# Altium schematic sheet IMX6UL_ALPHA_ENET2.SchDoc(IMX6UL_ALPHA_ENET2)
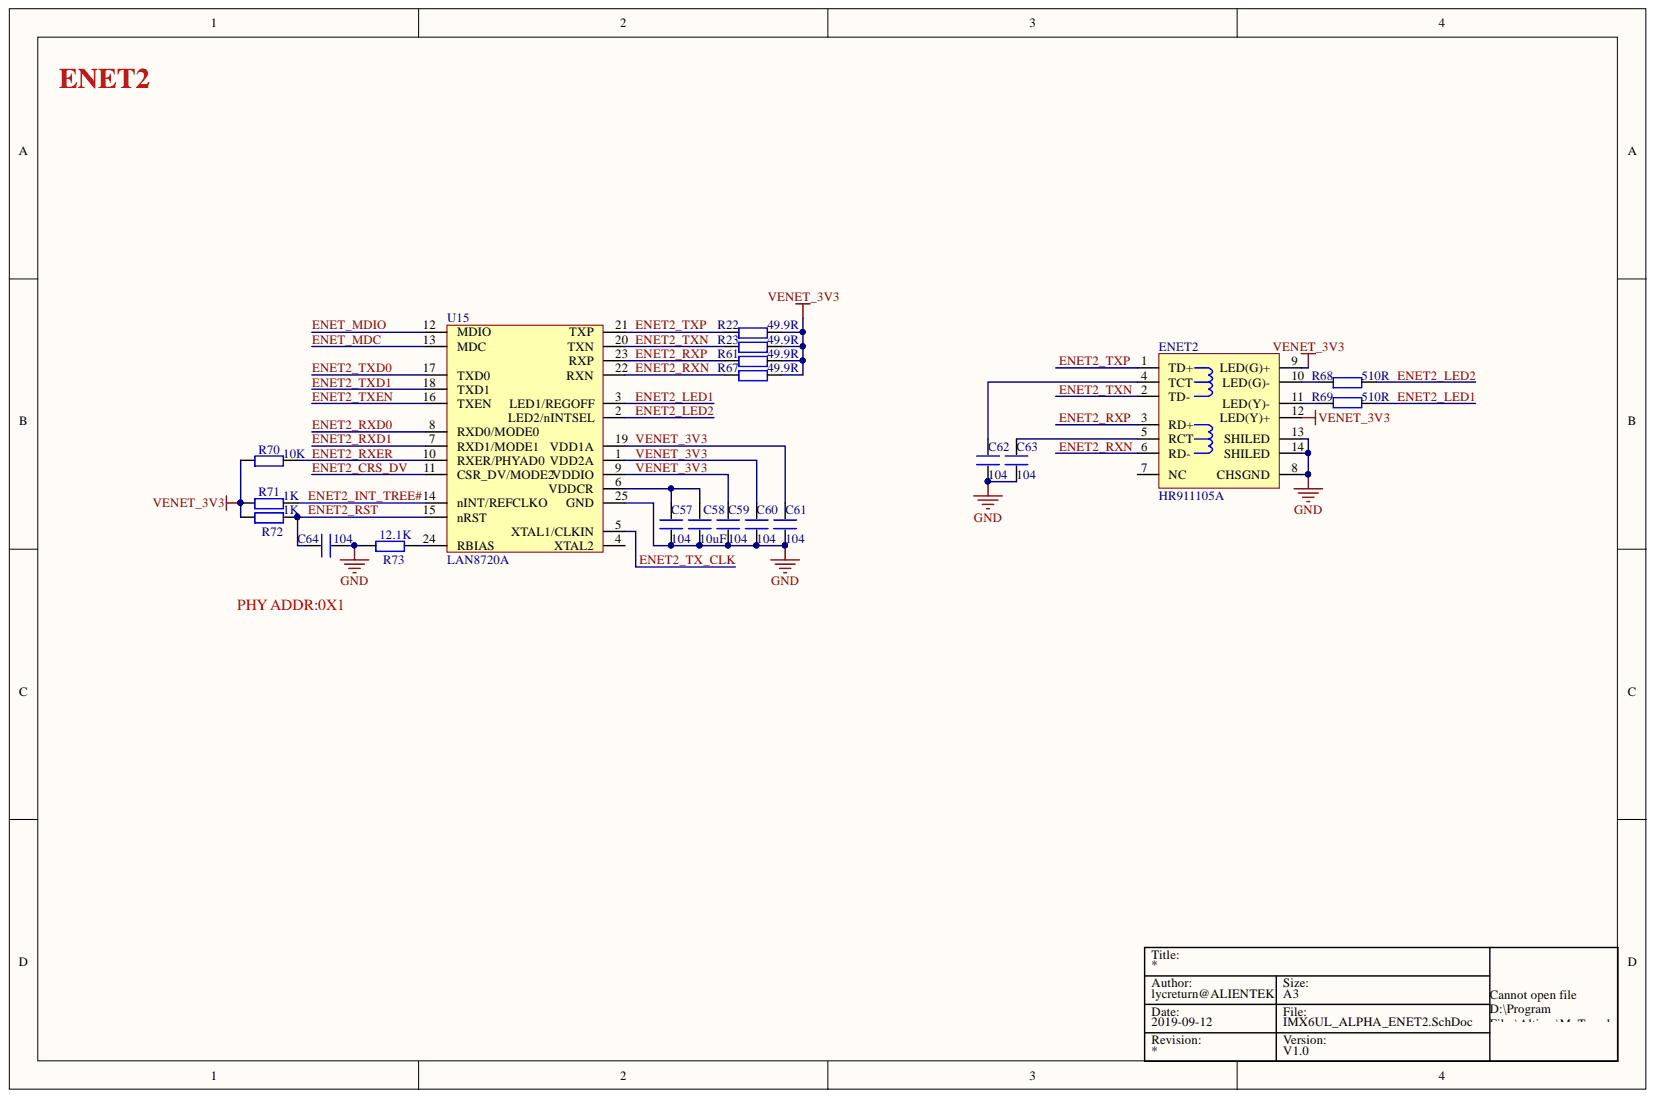
[diagram p0: IMX6UL_ALPHA_ENET2.SchDoc]

## Parts
C57  104  [C 0603]
C58  10uF  [C 0805]
C59  104  [C 0603]
C60  104  [C 0603]
C61  104  [C 0603]
C62  104  [C 0603]
C63  104  [C 0603]
C64  104  [C 0603]
ENET2  HR911105A  [RJ-45A12]
R22  49.9R  [R 0603]
R23  49.9R  [R 0603]
R61  49.9R  [R 0603]
R67  49.9R  [R 0603]
R68  510R  [R 0603]
R69  510R  [R 0603]
R70  10K  [R 0603]
R71  1K  [R 0603]
R72  1K  [R 0603]
R73  12.1K  [R 0603]
U15  LAN8720A  [QFN24]

## Nets
GND: C57.2 C58.2 C59.2 C60.2 C61.2 C62.1 C63.1 C64.1 ENET2.8(CHSGND) ENET2.13(SHILED) ENET2.14(SHILED) R73.1 U15.25(GND)
ENET2_CRS_DV: U15.11(CSR_DV/MODE2)
ENET2_INT_TREE#: R71.2 U15.14(nINT/REFCLKO)
ENET2_LED1: R69.1 U15.3(LED1/REGOFF)
ENET2_LED2: R68.2 U15.2(LED2/nINTSEL)
ENET2_RST: C64.2 R72.2 U15.15(nRST)
ENET2_RXD0: U15.8(RXD0/MODE0)
ENET2_RXD1: U15.7(RXD1/MODE1)
ENET2_RXER: R70.2 U15.10(RXER/PHYAD0)
ENET2_RXN: ENET2.6(RD-) R67.1 U15.22(RXN)
ENET2_RXP: ENET2.3(RD+) R61.1 U15.23(RXP)
ENET2_TX_CLK: U15.5(XTAL1/CLKIN)
ENET2_TXD0: U15.17(TXD0)
ENET2_TXD1: U15.18(TXD1)
ENET2_TXEN: U15.16(TXEN)
ENET2_TXN: ENET2.2(TD-) R23.1 U15.20(TXN)
ENET2_TXP: ENET2.1(TD+) R22.1 U15.21(TXP)
ENET_MDC: U15.13(MDC)
ENET_MDIO: U15.12(MDIO)
VENET_3V3: C59.1 C60.1 C61.1 ENET2.9(LED(G)+) ENET2.12(LED(Y)+) R22.2 R23.2 R61.2 R67.2 R70.1 R71.1 R72.1 U15.1(VDD2A) U15.9(VDDIO) U15.19(VDD1A)
NetC57_1: C57.1 C58.1 U15.6(VDDCR)
NetC62_2: C62.2 ENET2.4(TCT)
NetC63_2: C63.2 ENET2.5(RCT)
NetENET2_10: ENET2.10(LED(G)-) R68.1
NetENET2_11: ENET2.11(LED(Y)-) R69.2
NetR73_2: R73.2 U15.24(RBIAS)

Not connected: ENET2 7(NC); U15 4(XTAL2)

## Library data of the parts
C57-C64 = C: Component Kind: Standard; Library Name: 电阻-电容-电感.SchLib
ENET2 = HR911105A: 带灯以太网接口; 版权归属: 源创客; BOM名称: 带灯以太网接口; Component Kind: Standard; Library Name: 接口类.SchLib; 学习资源: www.ooocke.com
R22 R23 R61 R67-R73 = R: Component Kind: Standard; Library Name: 电阻-电容-电感.SchLib
U15 = LAN8720: ETH PHY; Component Kind: Standard; Library Name: IMX6UL_ZERO_V1.0.SCHLIB
